# Altium schematic sheet Project North Star - MCU.SchDoc
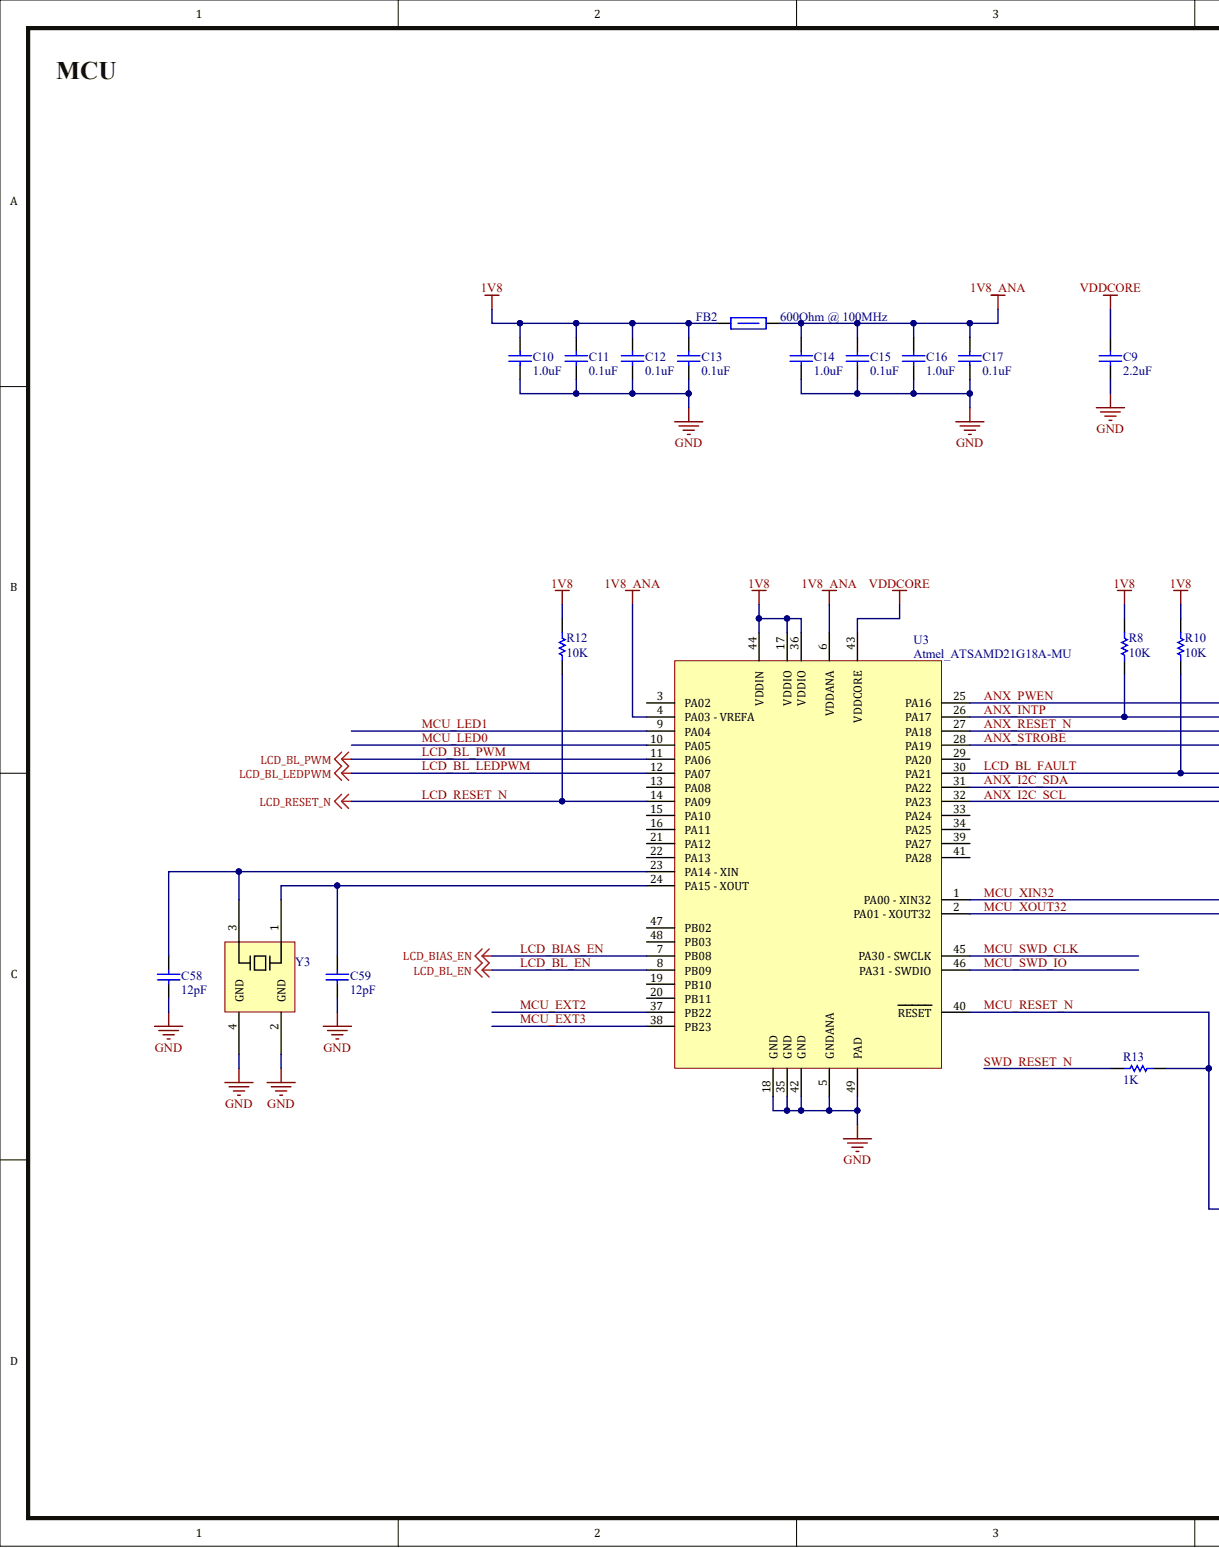
[diagram p0: Project North Star - MCU.SchDoc, left]
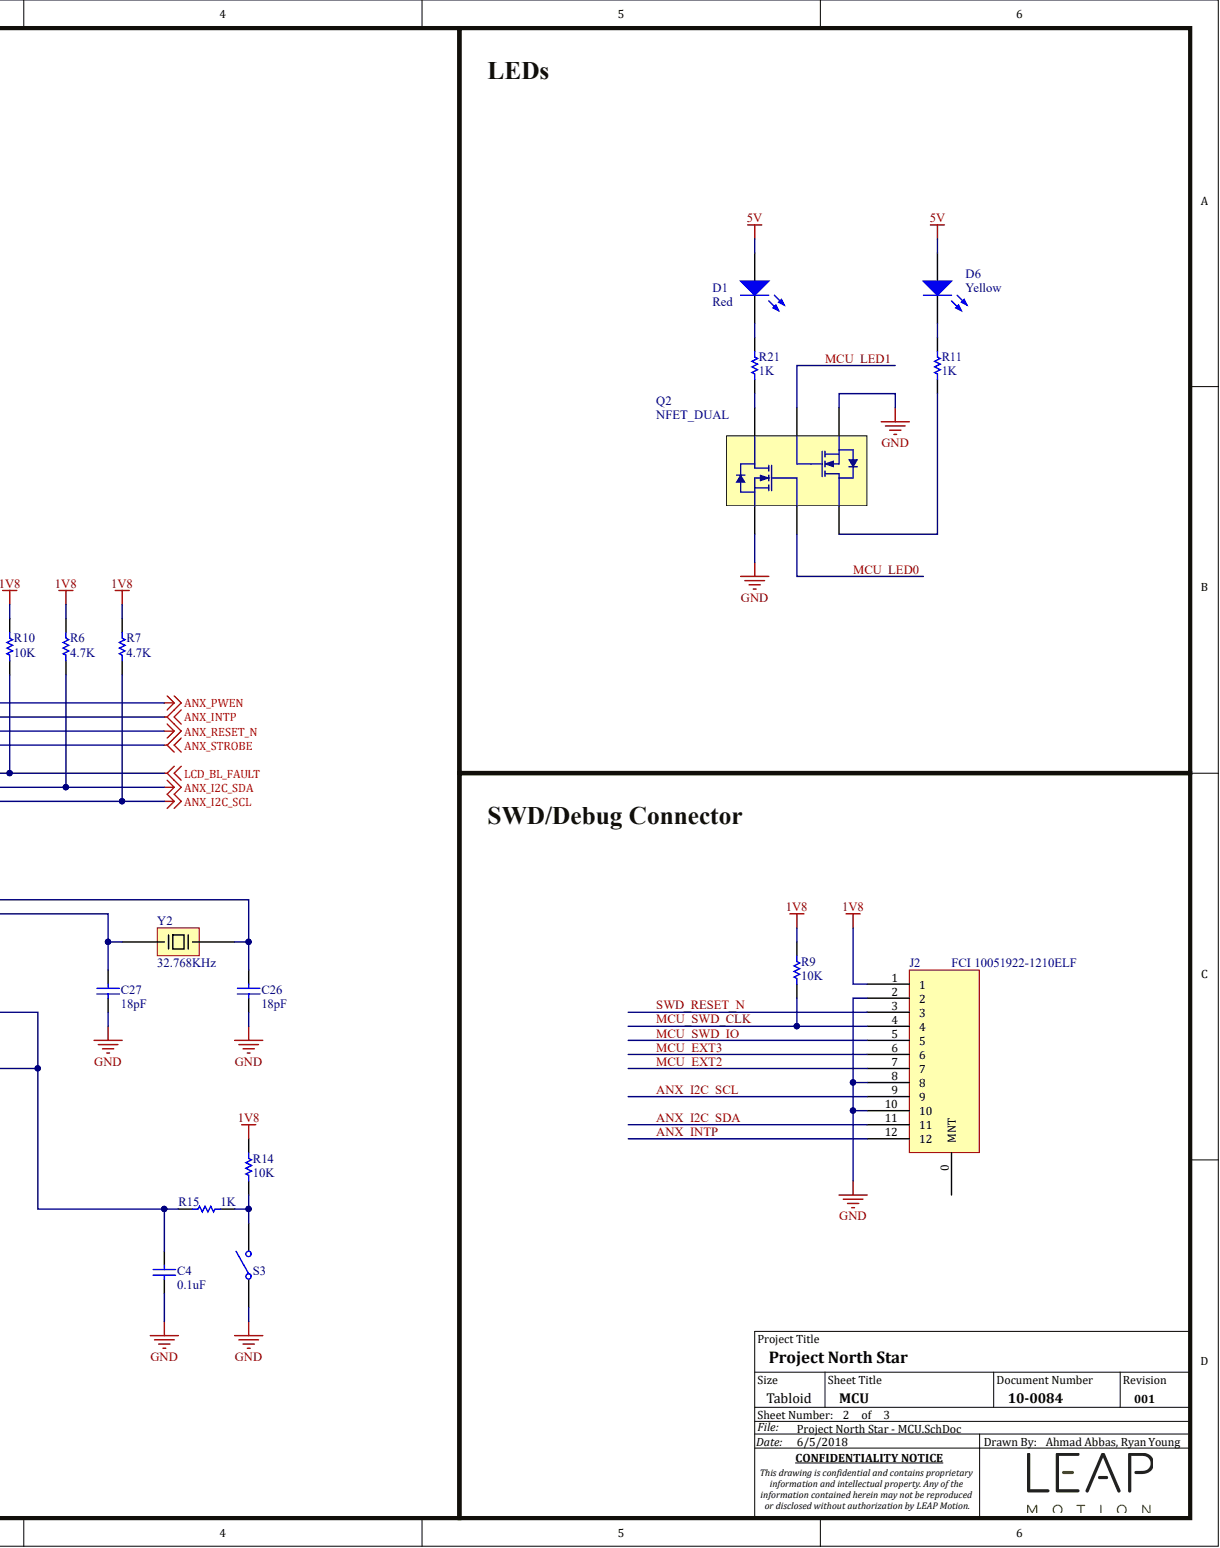
[diagram p1: Project North Star - MCU.SchDoc, right]

## Parts
C4  0.1uF  [CAPC0201(0603)33_N]
C9  2.2uF  [CAPC0402(1005)60_N]
C10  1.0uF  [CAPC0402(1005)60_N]
C11  0.1uF  [CAPC0201(0603)33_N]
C12  0.1uF  [CAPC0201(0603)33_N]
C13  0.1uF  [CAPC0201(0603)33_N]
C14  1.0uF  [CAPC0402(1005)60_N]
C15  0.1uF  [CAPC0201(0603)33_N]
C16  1.0uF  [CAPC0402(1005)60_N]
C17  0.1uF  [CAPC0201(0603)33_N]
C26  18pF  [CAPC0201(0603)33_N]
C27  18pF  [CAPC0201(0603)33_N]
C58  12pF  [CAPC0201(0603)33_N]
C59  12pF  [CAPC0201(0603)33_N]
D1  Color: Red  [Dialight_598-8010-107F]  (hidden comment: Value)
D6  Color: Yellow  [Dialight_598-8040-107F]  (hidden comment: Value)
FB2  Ferrite Bead  [INDC1608X09N]  (value not drawn)
J2  FCI 10051922-1210ELF  Manufacturer: FCI  Manufacturer P/N: 10051922-1210ELF  [FCI 10051922-1210ELF]
Q2  NFET_DUAL  [SOT-563]
R6  4.7K  [RESC0201(0603)_N]
R7  4.7K  [RESC0201(0603)_N]
R8  10K  [RESC0201(0603)_N]
R9  10K  [RESC0201(0603)_N]
R10  10K  [RESC0201(0603)_N]
R11  1K  [RESC0201(0603)_N]
R12  10K  [RESC0201(0603)_N]
R13  1K  [RESC0201(0603)_N]
R14  10K  [RESC0201(0603)_N]
R15  1K  [RESC0201(0603)_N]
R21  1K  [RESC0201(0603)_N]
S3  SW-SPST  [4.6mm x 3.2mm]  (value not drawn)
U3  Atmel_ATSAMD21G18A-MU  [Atmel_ATSAMD21G18A-MU]
Y2  Frequency: 32.768KHz  [Abracon_ABS07]  (hidden comment: Crystal_2pin)
Y3  Crystal  [Abracon_ABM11]  (value not drawn)

## Nets
1V8: C10.2 C11.2 C12.2 C13.2 FB2.1 J2.1 R6.2 R7.2 R8.2 R9.2 R10.2 R12.2 R14.2 U3.17(VDDIO) U3.36(VDDIO) U3.44(VDDIN)
1V8_ANA: C14.2 C15.2 C16.2 C17.2 FB2.2 U3.4(PA03_-_VREFA) U3.6(VDDANA)
5V: D1.A D6.A
GND: C4.1 C9.2 C10.1 C11.1 C12.1 C13.1 C14.1 C15.1 C16.1 C17.1 C26.2 C27.2 C58.2 C59.2 J2.2 J2.8 J2.10 Q2.1 Q2.4 S3.1 U3.5(GNDANA) U3.18(GND) U3.35(GND) U3.42(GND) U3.49(PAD) Y3.2(GND) Y3.4(GND)
VDDCORE: C9.1 U3.43(VDDCORE)
ANX_I2C_SCL: J2.9 R7.1 U3.32(PA23)
ANX_I2C_SDA: J2.11 R6.1 U3.31(PA22)
ANX_INTP: J2.12 R8.1 U3.26(PA17)
ANX_PWEN: U3.25(PA16)
ANX_RESET_N: U3.27(PA18)
ANX_STROBE: U3.28(PA19)
LCD_BIAS_EN: U3.7(PB08)
LCD_BL_EN: U3.8(PB09)
LCD_BL_FAULT: R10.1 U3.30(PA21)
LCD_BL_LEDPWM: U3.12(PA07)
LCD_BL_PWM: U3.11(PA06)
LCD_RESET_N: R12.1 U3.14(PA09)
MCU_EXT2: J2.7 U3.37(PB22)
MCU_EXT3: J2.6 U3.38(PB23)
MCU_LED0: Q2.2 U3.10(PA05)
MCU_LED1: Q2.5 U3.9(PA04)
MCU_RESET_N: C4.2 R13.2 R15.2 U3.40(RESET)
MCU_SWD_CLK: J2.4 R9.1 U3.45(PA30_-_SWCLK)
MCU_SWD_IO: J2.5 U3.46(PA31_-_SWDIO)
MCU_XIN32: C26.1 U3.1(PA00_-_XIN32) Y2.1
MCU_XOUT32: C27.1 U3.2(PA01_-_XOUT32) Y2.0
SWD_RESET_N: J2.3 R13.1
NetC58_1: C58.1 U3.23(PA14_-_XIN) Y3.3
NetC59_1: C59.1 U3.24(PA15_-_XOUT) Y3.1
NetD1_K: D1.K R21.1
NetD6_K: D6.K R11.1
NetQ2_3: Q2.3 R11.2
NetQ2_6: Q2.6 R21.2
NetR14_1: R14.1 R15.1 S3.2

Not connected: J2 0(MNT); U3 3(PA02) 13(PA08) 15(PA10) 16(PA11) 19(PB10) 20(PB11) 21(PA12) 22(PA13) 29(PA20) 33(PA24) 34(PA25) 39(PA27) 41(PA28) 47(PB02) 48(PB03)

## Library data of the parts
C4 C11-C13 C15 C17 = CAP_0060: Capacitor, 0.1uF, 10V, 10%, 0201, Ceramic, X5R; Manufacturer: Murata; Manufacturer P/N: GRM033R61A104KE15D; Database Table Name: Capacitors; Polarity: No; Temp Range: -55C - 85C; Component Kind: Standard; Datasheet: http://www.mouser.com/ds/2/281/c02e-2905.pdf; Date: 6/29/2016; Dielectric: X5R; Display: 0.1uF, 10V; Material: Ceramic; Max Height: 0.15mm; P/N: CAP_0060; Package: 0201; RoHS: True; Supplier: Mouser; Supplier P/N: 581-81-GRM033R61A104KE5D; Supplier Price: 0.1; Tolerance: 10%; Value: 0.1uF; Voltage Rating: 10V; Author: AA; Library Name: LEAPdb.DbLib
C9 = CAP_0016: Capacitor, 2.2uF, 6.3V, 20%, 0402, Ceramic, X5R; Manufacturer: TDK; Manufacturer P/N: C1005X5R0J225M050BC; Database Table Name: Capacitors; Polarity: No; Temp Range: -55C - 85C; Component Kind: Standard; Datasheet: http://www.tdk.com/pdf/TDKMLCCCapRange.pdf; Date: 4/30/2013; Dielectric: X5R; Display: 2.2uF, 6.3V; Material: Ceramic; Max Height: 0.55mm; P/N: CAP_0016; Package: 0402; RoHS: True; Supplier: Digikey; Supplier P/N: 445-4999-1-ND; Supplier Price: 0.27; Tolerance: 20%; Value: 2.2uF; Voltage Rating: 6.3V; Author: NR; Library Name: LEAPdb.DbLib
C10 C14 C16 = CAP_0023: Capacitor, 1.0uF, 10V, 10%, 0402, Ceramic, X5R; Manufacturer: TDK; Manufacturer P/N: C1005X5R1A105K050BB; Database Table Name: Capacitors; Polarity: No; Temp Range: -55C - 85C; Component Kind: Standard; Datasheet: http://product.tdk.com/capacitor/mlcc/en/documents/mlcc_commercial_general_en.pdf; Date: 8/28/2013; Dielectric: X5R; Display: 1.0uF, 10V; Material: Ceramic; Max Height: 0.55mm; P/N: CAP_0023; Package: 0402; RoHS: True; Supplier: Digikey; Supplier P/N: 445-4114-6-ND; Supplier Price: 0.1; Tolerance: 10%; Value: 1.0uF; Voltage Rating: 10V; Author: NR; Library Name: LEAPdb.DbLib
C26 C27 = CAP_0066: Capacitor, 18pF, 50V, 2%, 0201, Ceramic, C0G(NP0); Manufacturer: Murata; Manufacturer P/N: GRM0335C1H180GA01D; Database Table Name: Capacitors; Polarity: No; Temp Range: -55C - 125C; Component Kind: Standard; Datasheet: http://search.murata.co.jp/Ceramy/image/img/A01X/G101/ENG/GRM0335C1H6R0BA01-01.pdf; Date: 7/19/2017; Dielectric: C0G(NP0); Display: 18pF, 50V; Material: Ceramic; Max Height: 0.15mm; P/N: CAP_0066; Package: 0201; RoHS: True; Supplier: Digikey; Supplier P/N: 490-11310-1-ND; Supplier Price: 0.1; Tolerance: 2%; Value: 18pF; Voltage Rating: 50V; Author: RY; Library Name: LEAPdb.DbLib
C58 C59 = CAP_0065: Capacitor, 12pF, 50V, 2%, 0201, Ceramic, C0G(NP0); Manufacturer: Murata; Manufacturer P/N: GRM0335C1H120GA01D; Database Table Name: Capacitors; Polarity: No; Temp Range: -55C - 125C; Component Kind: Standard; Datasheet: http://search.murata.co.jp/Ceramy/image/img/A01X/G101/ENG/GRM0335C1H6R0BA01-01.pdf; Date: 7/19/2017; Dielectric: C0G(NP0); Display: 12pF, 50V; Material: Ceramic; Max Height: 0.15mm; P/N: CAP_0065; Package: 0201; RoHS: True; Supplier: Digikey; Supplier P/N: 490-11308-1-ND; Supplier Price: 0.1; Tolerance: 2%; Value: 12pF; Voltage Rating: 50V; Author: RY; Library Name: LEAPdb.DbLib
D1 = LED_0017: LED, 635nm, 140deg, , 20mA, , 1.6mm x 0.8mm, -30C - 85C; Manufacturer: Dialight; Manufacturer P/N: 598-8010-107F; Database Table Name: LEDs; Radiant Intensity (mW/sr): 40mcd; Vf: 1.7V; Center Wavelength: 635nm; Class: LED; Component Kind: Standard; Datasheet: http://www.mouser.com/catalog/specsheets/SMT_selector_guide.pdf; Date: 1/20/2014; Display: LED, 635nm, 140deg; Half Angle: 140deg; If: 20mA; If_Surge: 20mA; Max Height: 0.7mm; P/N: LED_0017; Package: 1.6mm x 0.8mm; RoHS: True; Supplier: Mouser; Supplier P/N: 645-598-8010-107F; Supplier Price: 0.45; Temp Range: -30C - 85C; Author: JDS; Library Name: LEAPdb.DbLib
D6 = LED_0034: LED, 593nm, 140deg, , 20mA, , 1.6mm x 0.8mm, -30C - 85C; Manufacturer: Dialight; Manufacturer P/N: 598-8040-107F; Database Table Name: LEDs; Radiant Intensity (mW/sr): 130mcd; Vf: 2V; Center Wavelength: 593nm; Class: LED; Component Kind: Standard; Datasheet: http://www.mouser.com/catalog/specsheets/SMT_selector_guide.pdf; Date: 11/11/2015; Display: LED, 593nm, 140deg; Half Angle: 140deg; If: 20mA; If_Surge: 20mA; Max Height: 0.7mm; P/N: LED_0034; Package: 1.6mm x 0.8mm; RoHS: True; Supplier: Mouser; Supplier P/N: 645-598-8040-107F; Supplier Price: 0.45; Temp Range: -30C - 85C; Author: JDS; Library Name: LEAPdb.DbLib
FB2 = FB_0001: FERRITE CHIP BEAD 600 OHM SMD; Manufacturer: TDK; Manufacturer P/N: MPZ1608S601A; Current Rating: 1A; Database Table Name: Ferrite Beads; DCR: 150mOhm; Impedance @ Freq: 600Ohm @ 100MHz; Component Kind: Standard; Datasheet: http://www.tdk.co.jp/tefe02/e9413_mpz.pdf; Date: 2/27/2013; Operating Temp Range: -55C - 125C; P/N: FB_0001; Package: 0603; Supplier: Digikey; Supplier P/N: 445-2205-1-ND; Supplier Price: 0.09; Author: NR; Library Name: LEAPdb.DbLib
J2 = CONN_0043: Connector, FCI, Right Angle, 12POS, 0.5mm; Database Table Name: Connectors; Mounting Height: 1.3mm; Rows: 1; Temp Range: -55C - 85C; Component Kind: Standard; Datasheet: http://portal.fciconnect.com/Comergent//fci/drawing/10051922.pdf; Date: 6/3/2014; Direction: Right Angle; Display Value: FCI 10051922-1210ELF; Mounting Type: SMD; P/N: CONN_0043; Pins: 12; Pitch: 0.5mm; RoHS: True; Supplier: Digikey; Supplier P/N: 609-1236-6-ND; Supplier Price: 0.53; Type: FPC; Author: NR; Library Name: LEAPdb.DbLib
Q2 = NFET_0009: Transistor, NFET_DUAL, 2.2Ohm, 200mA, 120mW, 	SOT-563, -55C - 150C; Manufacturer: Rohm Semiconductor; Manufacturer P/N: EM6K34T2CR; Database Table Name: FETs; Display: NFET_DUAL, 	SOT-563; Component Kind: Standard; Datasheet: http://rohmfs.rohm.com/en/products/databook/datasheet/discrete/transistor/mosfet/em6k34.pdf; Date: 7/19/2017; Drain Current: 200mA; Max Height: 0.5mm; P/N: NFET_0009; Package: SOT-563; Power Rating: 120mW; Rds: 2.2Ohm; RoHS: True; Supplier: Digikey; Supplier P/N: EM6K34T2CRCT-ND; Supplier Price: 0.45; Temp Range: -55C - 150C; Author: RY; Library Name: LEAPdb.DbLib
R6 R7 = RES_0040: Resistor, 4.7K, 1/20W, 1%, 0201; Manufacturer: Panasonic Electronic Components; Manufacturer P/N: ERJ-1GEF4701C; Database Table Name: Resistors; Display Value: 4.7K, 0201; Temp Range: -55C - 125C; Component Kind: Standard; Date: 8/9/2013; Max Height: 0.26mm; P/N: RES_0040; Package: 0201; Power Rating: 1/20W; RoHS: True; Supplier: Digikey; Supplier P/N: P4.70KABDKR-ND; Supplier Price: 0.11; Tolerance: 1%; Value: 4.7K; Author: NR; Library Name: LEAPdb.DbLib
R8-R10 R12 R14 = RES_0014: Resistor, 10K, 1/20W, 5%, 0201; Manufacturer: Panasonic Electronic Components; Manufacturer P/N: ERJ-1GEJ103C; Database Table Name: Resistors; Display Value: 10K, 0201; Temp Range: -55C - 155C; Component Kind: Standard; Datasheet: http://industrial.panasonic.com/www-data/pdf/AOA0000/AOA0000CE2.pdf#http://industrial.panasonic.com/www-data/pdf/AOA0000/AOA0000CE2.pdf; Date: 2/25/2013; Max Height: 0.26mm; P/N: RES_0014; Package: 0201; Power Rating: 1/20W; RoHS: True; Supplier: Digikey; Supplier P/N: P10KAGCT-ND; Supplier Price: 0.1; Tolerance: 5%; Value: 10K; Author: NR; Library Name: LEAPdb.DbLib
R11 R13 R15 R21 = RES_0036: Resistor, 1K, 1/20W, 5%, 0201; Manufacturer: Panasonic Electronic Components; Manufacturer P/N: ERJ-1GEJ102C; Database Table Name: Resistors; Display Value: 1K, 0201; Temp Range: -55C - 125C; Component Kind: Standard; Date: 7/25/2013; Max Height: 0.26mm; P/N: RES_0036; Package: 0201; Power Rating: 1/20W; RoHS: True; Supplier: Digikey; Supplier P/N: P1.0KAGCT-ND; Supplier Price: 0.1; Tolerance: 5%; Value: 1K; Author: JDS; Library Name: LEAPdb.DbLib
S3 = SWT_0006: Switch, SPST-NO, Button, 4.6mm x 3.2mm; Manufacturer: ALPS; Manufacturer P/N: SKRPABE010; Database Table Name: Switches; Display: Switch, SW-SPST; Circuit: SPST-NO; Class: Switch; Component Kind: Standard; Datasheet: #http://www.alps.com/products/WebObjects/catalog.woa/E/HTML/Tact/SurfaceMount/SKRP/SKRPABE010.html#; Date: 3/11/2014; Operating Temperature: -30C - 85C; P/N: SWT_0006; RoHS: True; Supplier: Mouser; Supplier P/N: 688-SKRPAB; Supplier Price: 0.41; Switch Function: Button; Author: JDS; Library Name: LEAPdb.DbLib
U3 = IC_0197: IC MCU 32BIT 256KB FLASH 48QFN; Manufacturer: Atmel; Database Table Name: ICs; Component Kind: Standard; Datasheet: http://ww1.microchip.com/downloads/en/DeviceDoc/40001882A.pdf; Date: 7/13/2017; Manufacturer P/N: ATSAMD21G18A-MU; Operating Temp Range: -40C - 85C; P/N: IC_0197; Package: VQFN-48; Supplier: Digikey; Supplier P/N: ATSAMD21G18A-MU-ND; Supplier Price: 3.15; Author: RY; Library Name: LEAPdb.DbLib
Y2 = XTAL_0023: Crystal, 32.768KHz, 12.5pF, 2-SMD(3.2x1.5); Manufacturer: Abracon; Manufacturer P/N: ABS07-32.768KHZ-T; Database Table Name: Crystals; Tolerance: 20 ppm; Component Kind: Standard; Datasheet: http://www.mouser.com/ds/2/3/ABS07-11028.pdf; Date: 11/16/2015; Load Capacitance: 12.5pF; Operating Temp Range: -40C - 85C; P/N: XTAL_0023; Package: 2-SMD(3.2x1.5); Supplier: Mouser; Supplier P/N: 815-ABS07-32.768KHZ-T; Supplier Price: 0.63; Type: Crystal; Author: JDS; Library Name: LEAPdb.DbLib
Y3 = XTAL_0024: Crystal, 16MHz, 10pF, 4-SMD (2x1.6); Manufacturer: Abracon; Manufacturer P/N: ABM11-16.000MHZ-D2X-T3; Database Table Name: Crystals; Tolerance: 20ppm; Component Kind: Standard; Datasheet: http://www.abracon.com/Resonators/ABM11.pdf; Date: 3/28/2016; Frequency: 16MHz; Load Capacitance: 10pF; Operating Temp Range: -40C - 85C; P/N: XTAL_0024; Package: 4-SMD (2x1.6); Supplier: Digikey; Supplier P/N: 535-12629-1-ND; Supplier Price: 1.04; Type: Crystal; Author: JDS; Library Name: LEAPdb.DbLib
